# Altium schematic sheet LiPo_batteries_charger.SchDoc(LiPo_batteries_charger)
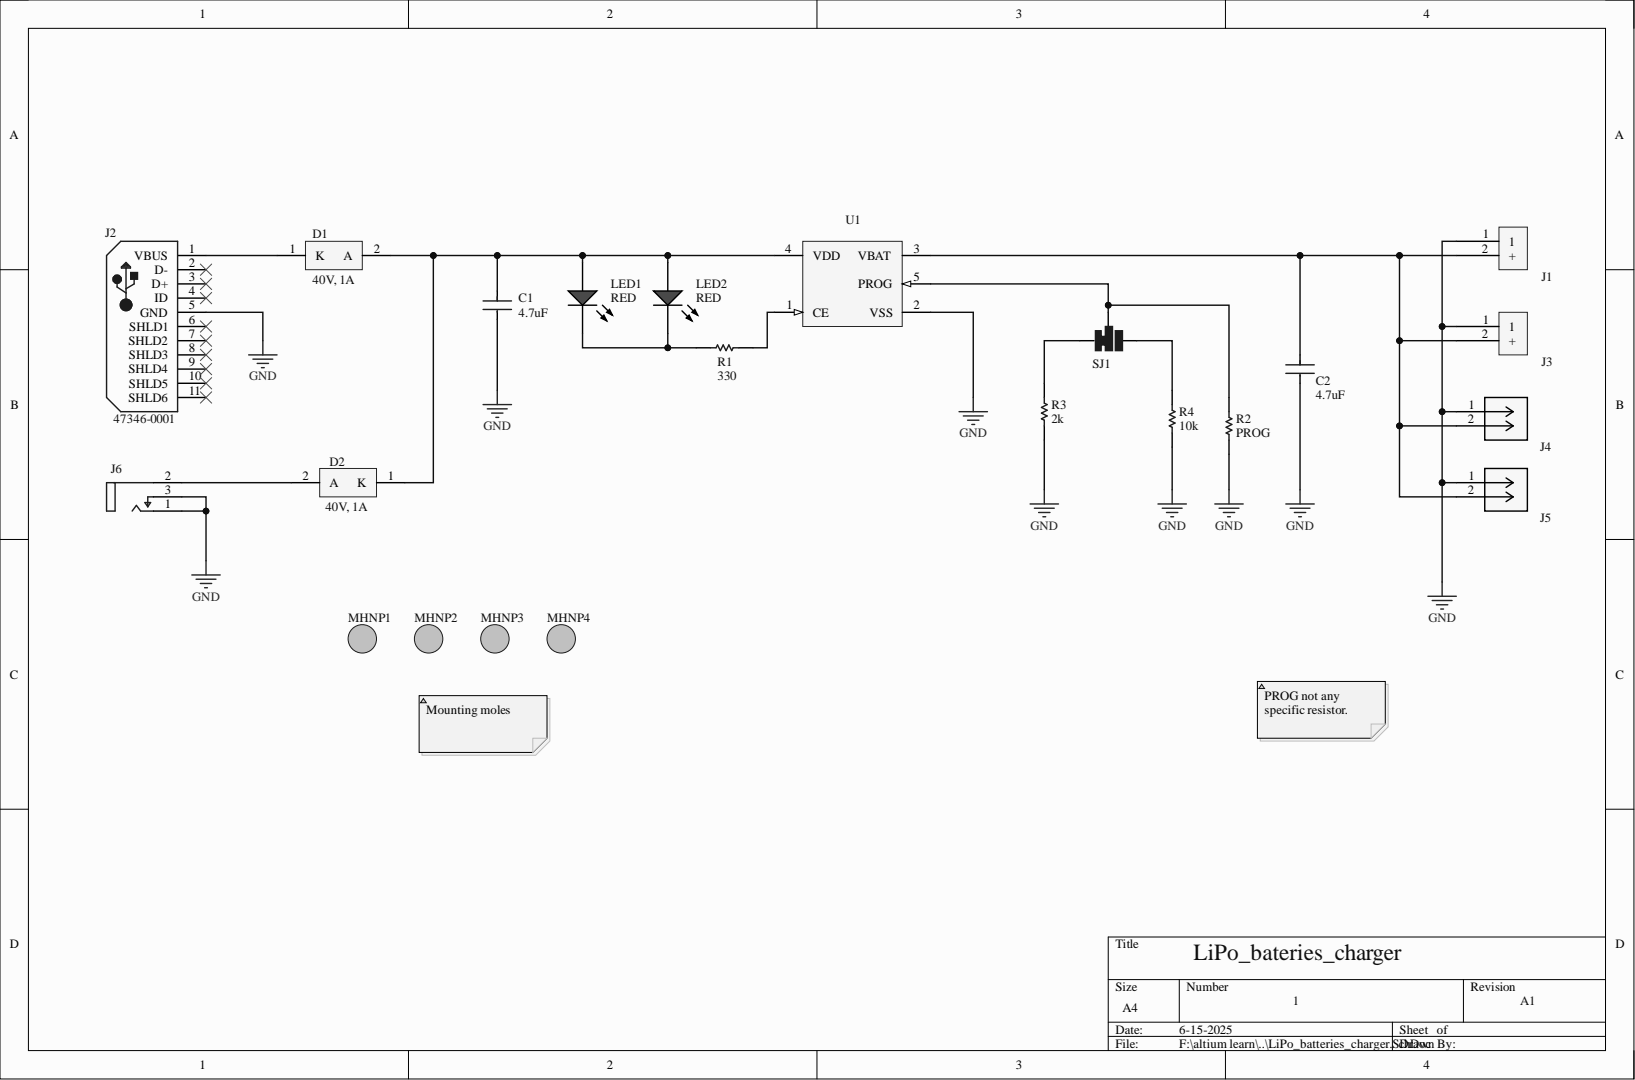
[diagram p0: LiPo_batteries_charger.SchDoc]

## Parts
C1  4.7uF  [FP-CC0805-0_85-MFG]
C2  4.7uF  [FP-CC0805-0_85-MFG]
D1  40V, 1A  [ONSC-SMA-2-403D-02_V]
D2  40V, 1A  [ONSC-SMA-2-403D-02_V]
J1  CONN HEADER R/A 2POS 5.08MM  [1-179276-2]  (value not drawn)
J2  47346-0001  [MOLX-47346-0001-5_V]
J3  CONN HEADER R/A 2POS 5.08MM  [1-179276-2]  (value not drawn)
J4  2 POS VERT HEADER 0.100"  [2Pins_AMP]  (value not drawn)
J5  2 POS VERT HEADER 0.100"  [2Pins_AMP]  (value not drawn)
J6  RASM722PTR13X  [FP-RASM722PTR13X-MFG]  (value not drawn)
LED1  RED  [VISH-TLMS1000_V]
LED2  RED  [VISH-TLMS1000_V]
MHNP1  Mounting Hole Non-Plated 3.2mm  [MTHNP3P2MM]  (value not drawn)
MHNP2  Mounting Hole Non-Plated 3.2mm  [MTHNP3P2MM]  (value not drawn)
MHNP3  Mounting Hole Non-Plated 3.2mm  [MTHNP3P2MM]  (value not drawn)
MHNP4  Mounting Hole Non-Plated 3.2mm  [MTHNP3P2MM]  (value not drawn)
R1  330  [RESC2013X50X30NL20T20]
R2  PROG  [VISH-A1-2_V2]
R3  2k  [RESC2013X70X40ML20T20]
R4  10k  [RESC2013X70X40ML20T20]
SJ1  SJUMPER3POS  [SJUMPER3POS-Footprint-1]  (value not drawn)
U1  Charge Management Controller  [SOT-23-OT5_M]  (value not drawn)

## Nets
GND: C1.2 C2.2 J1.1 J2.5(GND) J3.1 J4.1 J5.1 J6.1 J6.3 R2.1 R3.2 R4.2 U1.2(VSS)
NetC1_1: C1.1 D1.2 D2.1 LED1.1 LED2.1 U1.4(VDD)
NetC2_1: C2.1 J1.2 J3.2 J4.2 J5.2 U1.3(VBAT)
NetD1_1: D1.1 J2.1(VBUS)
NetD2_2: D2.2 J6.2
NetLED1_2: LED1.2 LED2.2 R1.1
NetR1_2: R1.2 U1.1(CE)
NetR2_2: R2.2 SJ1.2 U1.5(PROG)
NetR3_1: R3.1 SJ1.1
NetR4_1: R4.1 SJ1.3

Not connected: J2 2(D-) 3(D+) 4(ID) 6(SHLD1) 7(SHLD2) 8(SHLD3) 9(SHLD4) 10(SHLD5) 11(SHLD6)

## Library data of the parts
C1 C2 = CAP CER 4.7UF 6.3V X7R 0805: 4.7µF ±10% 6.3V Ceramic Capacitor X7R 0805 (2012 Metric); Manufacturer: Yageo; Manufacturer Part Number: CC0805KKX7R5BB475; Tolerance: 0.1; Automotive: No; Capacitance: 4.7 uF; Category: Cap; Component Kind: Standard; ComponentLink2Description: Datasheet; ComponentLink2URL: http://www.yageo.com/documents/recent/UPY-GPHC_Y5V_6.3V-to-50V_8.pdf; Device Class L1: Passive Components; Device Class L2: Capacitors; Device Class L3: Ceramic Capacitors; Digikey Description: CAP CER 4.7UF 6.3V X7R 0805; Footprint Url: http://www.yageo.com/exep/pages/download/literatures/UPY-C_GEN_24.pdf; Height: 0.95mm; Ipc Land Pattern Name: CAPC200125X85; Lead Free: Yes; Library Name: LiPoBattery_A.SCHLIB; Material: Ceramic; Mouser Description: Multilayer Ceramic Capacitors MLCC - SMD/SMT 4.7uF 6.3V X7R 10%; Octopart: https://octopart.com/cc0805kkx7r5bb475-yageo-27548069; Package: CC0805-0.85; RoHS: TRUE; Supplier 1: Digi-Key; Supplier 2: Digi-Key; Supplier Part Number 1: 311-3419-1-ND; Supplier Part Number 2: 311-3419-2-ND; Temperature: -30°C to +85°C; Temperature Characteristic: X7R; Temperature Range High: +85°C; Temperature Range Low: -30°C; Value: 4.7 uF; Voltage Rating: 6.3 V
D1 D2 = DIODE SCHOTTKY 40V 1A SMA: Schottky Power Rectifier, 2-Pin SMA, Pb-Free, Cut Tape, Diode Schottky 40V 1A Surface Mount SMA; Manufacturer: ON Semiconductor; Manufacturer Part Number: MBRA140T3G; Configuration: Single; IFSM Max (A): 30; Packing: Tape and Reel; Component Kind: Standard; ComponentLink1Description: Manufacturer URL; ComponentLink1URL: http://www.onsemi.com/; ComponentLink2Description: Datasheet; ComponentLink2URL: http://www.onsemi.cn/pub_link/Collateral/MBRA140T3-D.PDF; ComponentLink3Description: Package Specification; ComponentLink3URL: http://www.onsemi.com/pub_link/Collateral/403D-02.PDF; DatasheetVersion: Rev. 10; Library Name: LiPoBattery_A.SCHLIB; Mounting Technology: Surface Mount; PackageDescription: 2-Pin Small Molded Package, J-Bend Lead, Body 2.605 x 4.315 mm; PackageReference: SMA-2-403D-02; PackageVersion: Rev. G, 07/2012; PartNumber: MBRA140T3G; RoHS: TRUE; Supplier 1: Digi-Key; Supplier Part Number 1: MBRA140T3GOSCT-ND
J1 J3 = CONN HEADER R/A 2POS 5.08MM: Connector Header Through Hole, Right Angle 2 position 0.200" (5.08mm); Manufacturer: TE Connectivity AMP Connectors; Component Kind: Standard; ComponentLink1Description: Product URL; ComponentLink1URL: https://www.te.com/usa-en/product-1-179276-2.html?te_bu=Cor&te_type=other&te_campaign=oct_glo_manufacturer&elqCampaignId=26136; Library Name: LiPoBattery_A.SCHLIB; Manufacturer Part Number: 1-179276-2; Supplier 1: Digi-Key; Supplier Part Number 1: A29545-ND
J2 = CONN RCPT USB2.0 MICRO B SMD R/A: Micro-USB B Receptacle with Flange, -30 to 85 degC, 5-Pin SMD, RoHS, Tape and Reel; Manufacturer: Molex; Body Orientation: RightAngle; Gender: RCP; Maximum Current Rating: 1.8/ContactA; Maximum Voltage Rating: 30VAC; Number of Contacts: 5; Number of Ports: 1; Pitch: 0.65mm; Color: Black; Component Kind: Standard; Contact Material: CopperAlloy; Contact Plating: GoldOverPalladiumNickelOverNickel; Datasheet URL: https://datasheet.ciiva.com/30075/304740473460001-io-nectors-30075527.pdf; Datasheet Version: Dec-13; Dimension: 7.8x5.43x2.94mm; Housing Material: LiquidCrystalPolymer; Library Name: LiPoBattery_A.SCHLIB; Manufacturer Part Number: 47346-0001; Manufacturer URL: http://www.molex.com/; Mounting: SurfaceMount; Number of Terminals: 5; Operating Temperature: -30to85C; Packaging: EmbossedTapeandReel; RoHS: Yes; Supplier 1: Digi-Key; Supplier Part Number 1: WM17141CT-ND; Termination Method: Solder; Type: MicroUSBTypeB
J4 J5 = CONN HEADER VERT 2POS 0.100": Connector Header Through Hole 2 position; Manufacturer: Amphenol ICC (FCI); Manufacturer Part Number: 67997-402HLF; Built_By: EMA_UL_Team; SourceLibrary: Amphenol_2013-08-06_Bergstik_2Row_67997; Component Kind: Standard; Library Name: LiPoBattery_A.SCHLIB; RefDes: RefDes; Supplier 1: Digi-Key; Supplier Part Number 1: 609-5502-ND; Copyright: Copyright (C) 2018 Accelerated Designs. All rights reserved
J6 = CONN PWR JACK 2.1X5.5MM SOLDER: Power Barrel Connector Jack 2.10mm ID (0.083"), 5.50mm OD (0.217") Surface Mount, Right Angle; Manufacturer: Switchcraft; Current Rating: 5A; Gender: Male; Shielding: Unshielded; Case/Package: SMD/SMT; Color: Black; Component Kind: Standard; Connector Type: Jack; Contact Material: Copper Alloy; Contact Plating: Tin; Housing Color: Black; Housing Material: Thermoplastic; Library Name: LiPoBattery_A.SCHLIB; Manufacturer Part Number: RASM722PTR13X; Mount: Surface Mount; Package Quantity: 120; Supplier 1: Digi-Key; Supplier Part Number 1: SC2028-1-ND; Termination: Solder
LED1 LED2 = LED RED 0603 SMD: Low Current LED, 40 mW, 1.8 V, -40 to 100 degC, 2-Pin SMD (0603), RoHS, Tape and Reel; Manufacturer: Vishay; Manufacturer Part Number: TLMS1000-GS08; Automotive Qualification Standard: AEC-Q100; Bulb Size: 1.6mmx0.8mm; Forward Current If: 2mA; Forward Voltage: 1.8V; Lens Shape: Rectangular; Luminous Intensity: 4mcd; SVHC: ToBeAdvised; Viewing Angle: 80°; Wavelength Typ: 636nm; Component Kind: Standard; Datasheet URL: https://datasheet.ciiva.com/5798/1698881-5798337.pdf; Datasheet Version: Rev. 1.7, 02/2011; LED Colour: Red; LED Mounting: SMD; Library Name: LiPoBattery_A.SCHLIB; Manufacturer URL: http://www.vishay.com/; Mounting Technology: SurfaceMount; MSL: MSL2-1year; Package Description: 2-Pin Surface Mount Device, Body 1.6 x 0.8 mm; Package Reference: TLMS1000; Packaging: CutTape; Product Range: -; Supplier 1: Digi-Key; Supplier Part Number 1: TLMS1000-GS08CT-ND
MHNP1-MHNP4 = MTHNP3P2MM: Mounting Hole Non-Plated 3.2 mm drill hole; Component Kind: Standard; Library Name: LiPoBattery_A.SCHLIB; Manufacturer: N/A; Manufacturer Part Number: N/A
R1 = RES SMD 330 OHM 1% 1/8W 0805: 330 Ohms ±1% 0.125W, 1/8W Chip Resistor 0805 (2012 Metric) Automotive AEC-Q200 Thick Film; Manufacturer: Vishay; Manufacturer Part Number: CRCW0805330RFKEA; Component Kind: Standard; Library Name: LiPoBattery_A.SCHLIB; Supplier 1: Digi-Key; Supplier Part Number 1: 541-330CCT-ND
R2 = RES 62K OHM 2W 5% AXIAL: Power Metal Film Leaded Resistor, 62 KOhm +/-5%, 2 W, 2-Pin THD, RoHS, Ammo Pack62 kOhms ±5% 2W Through Hole Resistor Axial Automotive AEC-Q200, Flame Retardant Coating, Safety Metal Film; Manufacturer: Vishay Semiconductor; Manufacturer Part Number: PR02000206202JR500; PartNumber: PR02000206202JA100; Resistance: 62 KOhm; Temperature Coefficient: +/-250 ppm/degC; Component Kind: Standard; ComponentLink1Description: Manufacturer URL; ComponentLink1URL: http://www.vishay.com/; ComponentLink2Description: Datasheet; ComponentLink2URL: http://www.vishay.com/docs/28729/28729.pdf; ComponentLink3Description: Package Specification; ComponentLink3URL: http://www.vishay.com/docs/28729/28729.pdf; DatasheetVersion: Jun-13; Library Name: LiPoBattery_A.SCHLIB; Mounting Technology: Through Hole; PackageDescription: 2-Pin Axial Lead, Body 10 x 3.9 mm; Packing: Ammo Pack; Power: 2 W; RoHS: TRUE; Supplier 1: Digi-Key; Supplier Part Number 1: PPC62KW-2CT-ND; Tolerance: +/-5%
R3 = RES SMD 2K OHM 5% 1/8W 0805: 2 kOhms ±5% 0.125W, 1/8W Chip Resistor 0805 (2012 Metric) Automotive AEC-Q200 Thick Film; Manufacturer: Panasonic; Manufacturer Part Number: ERJ-6GEYJ202V; Component Kind: Standard; Library Name: LiPoBattery_A.SCHLIB; Supplier 1: Digi-Key; Supplier Part Number 1: P2.0KACT-ND
R4 = RES SMD 10K OHM 1% 1/8W 0805: 10 kOhms ±1% 0.125W, 1/8W Chip Resistor 0805 (2012 Metric) Automotive AEC-Q200 Thick Film; Manufacturer: Panasonic; Manufacturer Part Number: ERJ-6ENF1002V; Component Kind: Standard; Library Name: LiPoBattery_A.SCHLIB; Supplier 1: Digi-Key; Supplier Part Number 1: P10.0KCCT-ND
SJ1 = SJUMPER3POS: Solder jumper 3 pads and pins; Component Kind: Standard; Component Type: Miscellaneous; Library Name: LiPoBattery_A.SCHLIB; Manufacturer: N/A; Manufacturer Part Number: N/A; Pins: 3
U1 = IC LI-ION/LI-POLY CTRLR SOT23-5: Simple, Miniature Single-Cell, Fully Integrated Li-Ion/Li-Polymer Charge Management Controller, 4.2V, 5-Pin SOT-23, Industrial Temperature, Cut Tape; Manufacturer: Microchip; Manufacturer Part Number: MCP73811T-420I/OT; Code_IPC: SOT23-5; Component Kind: Standard; DatasheetVersion: revB, Sep-2007; Library Name: LiPoBattery_A.SCHLIB; PackageDescription: 5-Lead Plastic Small Outline Transistor (OT) [SOT-23]; PackageReference: SOT-23-OT5; PackageVersion: revBB, Aug-2009; Supplier 1: Digi-Key; Supplier Part Number 1: MCP73811T-420I/OTCT-ND
